# Altium schematic sheet Main.SchDoc
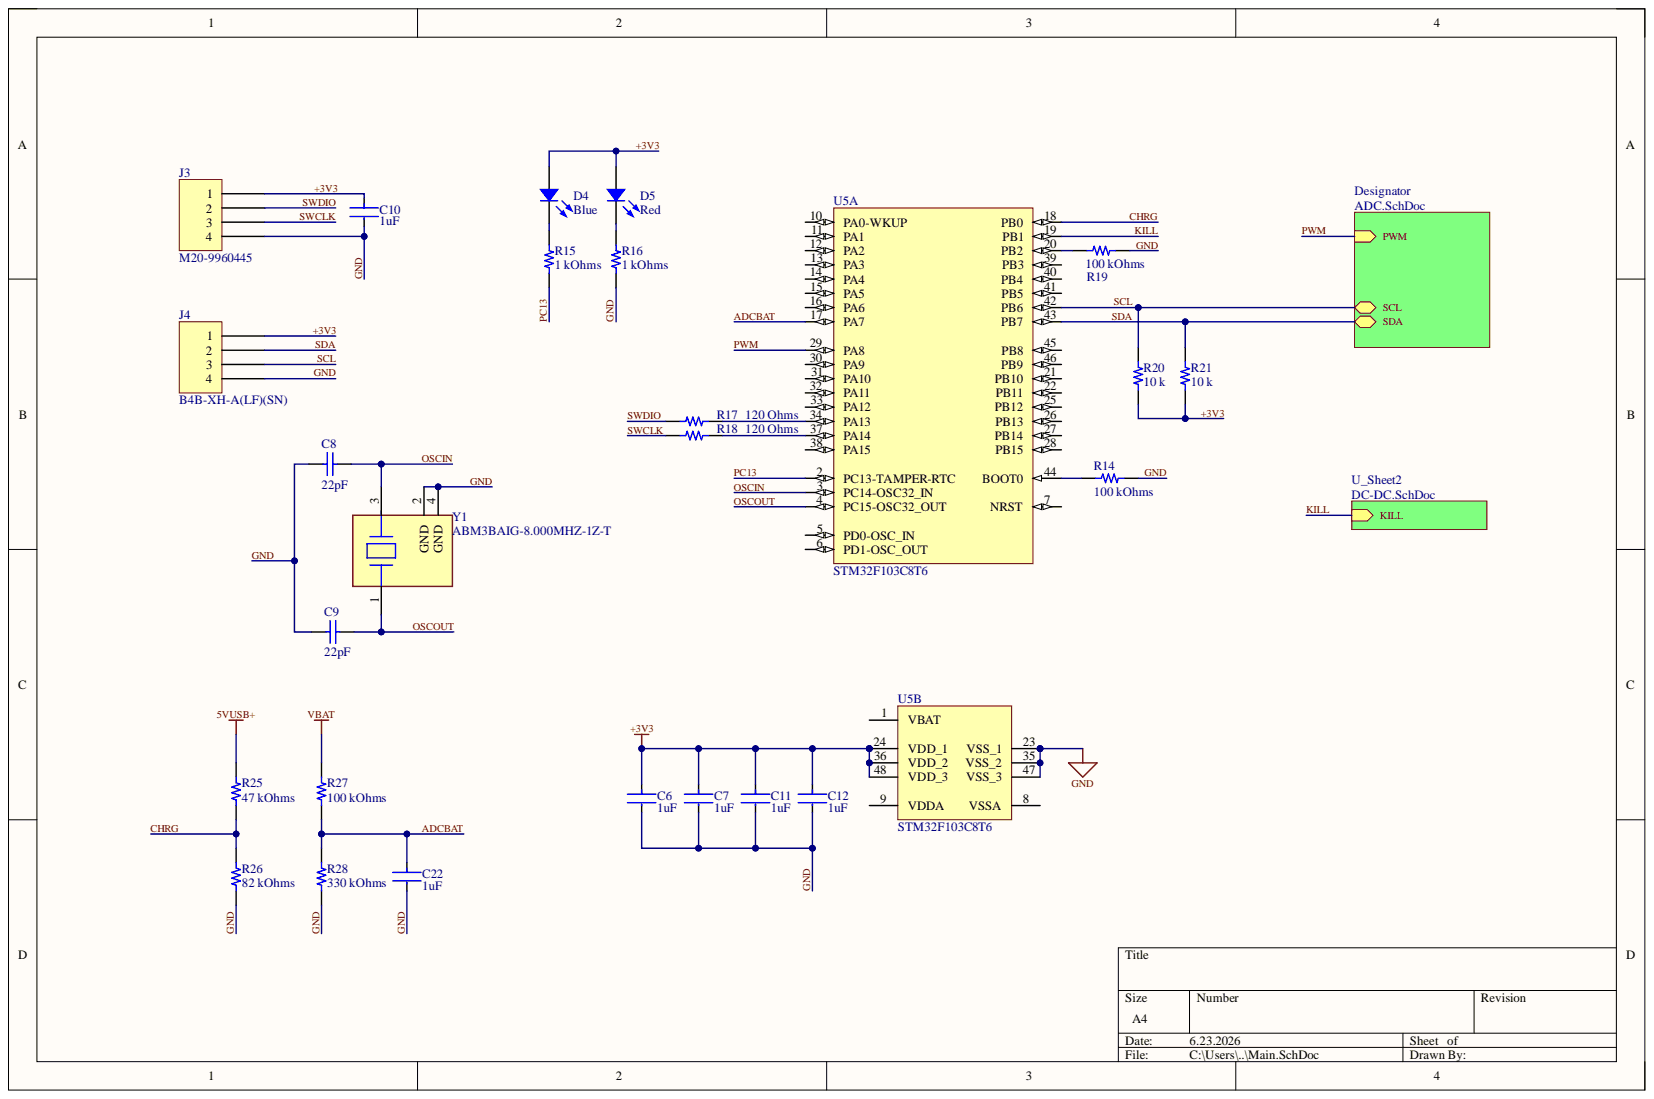
[diagram p0: Main.SchDoc]

## Parts
C6  Capacitance: 1uF  [FP-C0603C-CF-MFG]
C7  Capacitance: 1uF  [FP-C0603C-CF-MFG]
C8  22pF  [CAPC1608X90X35NL15T15]
C9  22pF  [CAPC1608X90X35NL15T15]
C10  Capacitance: 1uF  [FP-C0603C-CF-MFG]
C11  Capacitance: 1uF  [FP-C0603C-CF-MFG]
C12  Capacitance: 1uF  [FP-C0603C-CF-MFG]
C22  Capacitance: 1uF  [FP-C0603C-CF-MFG]
D4  Blue  [LED 0603_1608 YELLOW]
D5  Red  [LED 0603_1608 YELLOW]
J3  M20-9960445  [HARWIN M20-9960445]
J4  B4B-XH-A(LF)(SN)  [JST XH B4B-XH-A]
R14  100 kOhms  [KOA RES RK73 RN73 0603_1608]
R15  1 kOhms  [KOA RES RK73 RN73 0603_1608]
R16  1 kOhms  [KOA RES RK73 RN73 0603_1608]
R17  120 Ohms  [KOA RES RK73 RN73 0603_1608]
R18  120 Ohms  [KOA RES RK73 RN73 0603_1608]
R19  100 kOhms  [KOA RES RK73 RN73 0603_1608]
R20  10 k  [KOA RES RK73 RN73 0603_1608]
R21  10 k  [KOA RES RK73 RN73 0603_1608]
R25  47 kOhms  [KOA RES RK73 RN73 0603_1608]
R26  82 kOhms  [KOA RES RK73 RN73 0603_1608]
R27  100 kOhms  [KOA RES RK73 RN73 0603_1608]
R28  330 kOhms  [KOA RES RK73 RN73 0603_1608]
U5  STM32F103C8T6  [STM-LQFP48_L]  (parts A,B)
Y1  ABM3BAIG-8.000MHZ-1Z-T  [FP-ABM3BAIG-MFG]

## Nets
5VUSB+: R25.2
VBAT: R27.2
+3V3: C6.1 C7.1 C10.1 C11.1 C12.1 D4.1 D5.1 J3.1 J4.1 R20.1 R21.1 U5.24(VDD_1) U5.36(VDD_2) U5.48(VDD_3)
ADCBAT: C22.1 R27.1 R28.2 U5.17(PA7)
CHRG: R25.1 R26.2 U5.18(PB0)
GND: C6.2 C7.2 C8.1 C9.1 C10.2 C11.2 C12.2 C22.2 J3.4 J4.4 R14.1 R16.1 R19.2 R26.1 R28.1 U5.23(VSS_1) U5.35(VSS_2) U5.47(VSS_3) Y1.2(GND) Y1.4(GND)
KILL: U5.19(PB1)
OSCIN: C8.2 U5.3(PC14-OSC32_IN) Y1.3
OSCOUT: C9.2 U5.4(PC15-OSC32_OUT) Y1.1
PC13: R15.1 U5.2(PC13-TAMPER-RTC)
PWM: U5.29(PA8)
SCL: J4.3 R20.2 U5.42(PB6)
SDA: J4.2 R21.2 U5.43(PB7)
SWCLK: J3.3 R18.2
SWDIO: J3.2 R17.2
NetD4_2: D4.2 R15.2
NetD5_2: D5.2 R16.2
NetR14_2: R14.2 U5.44(BOOT0)
NetR17_1: R17.1 U5.34(PA13)
NetR18_1: R18.1 U5.37(PA14)
NetR19_1: R19.1 U5.20(PB2)
NetU5_1: U5.1(VBAT)
NetU5_5: U5.5(PD0-OSC_IN)
NetU5_6: U5.6(PD1-OSC_OUT)
NetU5_7: U5.7(NRST)
NetU5_8: U5.8(VSSA)
NetU5_9: U5.9(VDDA)
NetU5_10: U5.10(PA0-WKUP)
NetU5_11: U5.11(PA1)
NetU5_12: U5.12(PA2)
NetU5_13: U5.13(PA3)
NetU5_14: U5.14(PA4)
NetU5_15: U5.15(PA5)
NetU5_16: U5.16(PA6)
NetU5_21: U5.21(PB10)
NetU5_22: U5.22(PB11)
NetU5_25: U5.25(PB12)
NetU5_26: U5.26(PB13)
NetU5_27: U5.27(PB14)
NetU5_28: U5.28(PB15)
NetU5_30: U5.30(PA9)
NetU5_31: U5.31(PA10)
NetU5_32: U5.32(PA11)
NetU5_33: U5.33(PA12)
NetU5_38: U5.38(PA15)
NetU5_39: U5.39(PB3)
NetU5_40: U5.40(PB4)
NetU5_41: U5.41(PB5)
NetU5_45: U5.45(PB8)
NetU5_46: U5.46(PB9)

## Library data of the parts
C6 C7 C10-C12 C22 = CMP-2006-03086-2: C0603 1uF X8R 30ppm/°C 5.00% 50 V; Manufacturer: KEMET; Manufacturer Part Number: C0603C152J5HACTU; Automotive Grade: Grade 1; Material: Ceramic; Tolerance: 0.05; Value: 22uF; Voltage Rating: 50 V; Automotive: Yes; Category: Cap; Component Kind: Standard; ComponentLink2Description: Datasheet; ComponentLink2URL: https://api.kemet.com/component-edge/download/datasheet/C0603C100J5GACAUTO.pdf; Device Class L1: Passive Components; Device Class L2: Capacitors; Device Class L3: Ceramic Capacitors; Height: 0.87mm; Lead Free: Yes; Library Name: Altium Content Vault; Mouser Description: Cap Ceramic 0.0015uF 50V X8R 5% SMD 0603 150°C Paper T/R; Octopart: https://octopart.com/c0603c152j5hactu-kemet-12793173; Package: C0603C-CF; RoHS: TRUE; Temperature: -55°C to +125°C; Temperature Characteristic: X8R; Temperature Coefficient: 30ppm/°C; Temperature Range High: +125°C; Temperature Range Low: -55°C
C8 C9 = CMP-2006-04271-1: Manufacturer: AVX Interconnect / Elco; Part Number: 06035A220JAT4A; Component Kind: Standard; Library Name: Altium Content Vault
D4 D5 = LSM0603472V: LED RED CLEAR CHIP SMD; Manufacturer: Visual Communications Company - VCC; Color: Yellow; Configuration: Standard; Current - Test: 20mA; Database Table Name: LED - Indication; Lens Color: Colorless; Lens Size: 1.20mm x 0.80mm; Lens Style: Rectangle with Flat Top; Millicandela Rating: 110mcd; Viewing Angle: 120°; Voltage - Forward (Vf) (Typ): 2V; Wavelength - Dominant: 590nm; Component Kind: Standard; ComponentLink1Description: Datasheet; ComponentLink1URL: https://vcclite.com/wp-content/uploads/wpallimport/files/files/LSM0603472V%20(1).pdf; ComponentLink2Description: DigiKey Link; ComponentLink2URL: https://www.digikey.com/en/products/detail/visual-communications-company-vcc/LSM0603472V/9486726; Device Package: 0603 (1608 Metric); Height (Max): 0.65mm; LastUpdated: 05.04.2026 20:40:04; Lens Transparency: Clear; Library Name: Celestial Altium Library - altium_library.DbLib; Lifecycle Status: Active; Minimum Order: 1; Mounting Type: Surface Mount; Package / Case: 0603 (1608 Metric); Packaging: TapeAndReel; Part Number: LSM0603472V; Part Status: Active; PartId: 84; Price: 0.09; Series: LSM0603; Size / Dimension: 1.60mm L x 0.80mm W; Supplier 1: DigiKey; Supplier Device Package: Chip LED; Supplier Part Number 1: LSM0603472VCT-ND; Wavelength - Peak: 590nm
  D4: Value: Blue
  D5: Value: Red
J3 = M20-9960445: CONN HEADER R/A 4POS 2.54MM; Manufacturer: Harwin Inc.; Connector Type: Header, Cuttable; Contact Length - Mating: 0.240" (6.10mm); Contact Length - Post: 0.118" (3.00mm); Current Rating (Amps): 3A per Contact; Database Table Name: Connectors - Rectangular Headers Male Pins; Material Flammability Rating: UL94 V-0; Number of Positions: 4; Number of Positions Loaded: All; Pitch - Mating: 0.100" (2.54mm); Row Spacing - Mating: -40°C ~ 105°C; Shrouding: Unshrouded; Style: Board to Board or Cable; Component Kind: Standard; ComponentLink1Description: Datasheet; ComponentLink1URL: https://cdn.harwin.com/pdfs/Harwin_PC_Connectors_M20.pdf; ComponentLink2Description: DigiKey Link; ComponentLink2URL: https://www.digikey.com/en/products/detail/harwin-inc/M20-9960445/3921052; Contact Finish - Mating: Gold; Contact Material: Brass; Contact Shape: Square; Contact Type: Male Pin; Fastening Type: Push-Pull; Insulation Color: Black; Insulation Height: 0.100" (2.54mm); Insulation Material: Polyamide (PA6T), Nylon 6T; LastUpdated: 21.09.2022 19:23:20; Library Name: Celestial Altium Library - altium_library.DbLib; Lifecycle Status: Active; Minimum Order: 1; Mounting Type: Through Hole, Right Angle; Number of Rows: 1; Operating Temperature: -40°C ~ 105°C; Packaging: Bulk; Part Number: M20-9960445; Part Status: Active; PartId: 167; Price: 0.11; Series: M20; Supplier 1: DigiKey; Supplier Part Number 1: 952-3286-ND; Termination: Solder
J4 = B4B-XH-A(LF)(SN): CONN HEADER VERT 4POS 2.5MM; Manufacturer: JST Sales America Inc.; Contact Length - Post: 0.134" (3.40mm); Current Rating (Amps): 3A; Database Table Name: Connectors - Rectangular Headers Male Pins; Material Flammability Rating: UL94 V-0; Number of Positions: 4; Number of Positions Loaded: All; Pitch - Mating: 0.098" (2.50mm); Shrouding: Shrouded - 4 Wall; Style: Board to Cable/Wire; Voltage Rating: 250V; Component Kind: Standard; ComponentLink1Description: Datasheet; ComponentLink1URL: http://www.jst-mfg.com/product/pdf/eng/eXH.pdf; ComponentLink2Description: DigiKey Link; ComponentLink2URL: http://digikey.com/product-detail/en/jst-sales-america-inc/B4B-XH-A-LF-SN/455-2249-ND/1651047; Connector Type: Header; Contact Finish - Mating: Tin; Contact Finish - Post: Tin; Contact Material: Brass; Contact Shape: Square; Contact Type: Male Pin; Fastening Type: Detent Lock; Insulation Color: Natural; Insulation Height: 0.276" (7.00mm); Insulation Material: Polyamide (PA66), Nylon 6/6; LastUpdated: 12.01.2020 23:46:34; Library Name: Celestial Altium Library - altium_library.DbLib; Lifecycle Status: Active; Minimum Order: 1; Mounting Type: Through Hole; Number of Rows: 1; Operating Temperature: -25°C ~ 85°C; Packaging: Bulk; Part Number: B4B-XH-A(LF)(SN); Part Status: Active; PartId: 69; Price: 0.21; Series: XH; Supplier 1: DigiKey; Supplier Part Number 1: 455-2249-ND; Termination: Press-Fit, Solder
R14 R19 = RK73H1JTTD1153F: RES 100 KOHM 1% 1/10W 0603; Manufacturer: KOA Speer Electronics, Inc.; Database Table Name: Resistors - Surface Mount - 0603; Power (Watts): 0.1W, 1/10W; Temperature Coefficient: ±100ppm/°C; Component Kind: Standard; ComponentLink1Description: Datasheet; ComponentLink1URL: http://www.koaspeer.com/catimages/Products/RK73H/RK73H.pdf; ComponentLink2Description: DigiKey Link; ComponentLink2URL: https://www.digikey.com/en/products/detail/koa-speer-electronics-inc/RK73H1JTTD1153F/9845183; Composition: Thick Film; Device Package: 0603 (1608 Metric); Features: Automotive AEC-Q200; Height - Seated (Max): 0.022" (0.55mm); LastUpdated: 05.04.2026 21:23:47; Library Name: Celestial Altium Library - altium_library.DbLib; Lifecycle Status: Active; Minimum Order: 1; Number of Terminations: 2; Operating Temperature: -55°C ~ 155°C; Package / Case: 0603 (1608 Metric); Packaging: CutTape; Part Number: RK73H1JTTD1153F; Part Status: Active; PartId: 6643; Price: 0.01; Ratings: AEC-Q200; Resistance: 100 kOhms; Series: RK73H; Size / Dimension: 0.063" L x 0.031" W (1.60mm x 0.80mm); Supplier 1: DigiKey; Supplier Device Package: 0603; Supplier Part Number 1: 2019-RK73H1JTTD1153FCT-ND; Tolerance: ±1%; Value: 100 kOhms
R15 R16 = RK73H1JTTD1153F: RES 115K OHM 1% 1/10W 0603; Manufacturer: KOA Speer Electronics, Inc.; Database Table Name: Resistors - Surface Mount - 0603; Power (Watts): 0.1W, 1/10W; Temperature Coefficient: ±100ppm/°C; Component Kind: Standard; ComponentLink1Description: Datasheet; ComponentLink1URL: http://www.koaspeer.com/catimages/Products/RK73H/RK73H.pdf; ComponentLink2Description: DigiKey Link; ComponentLink2URL: https://www.digikey.com/en/products/detail/koa-speer-electronics-inc/RK73H1JTTD1153F/9845183; Composition: Thick Film; Device Package: 0603 (1608 Metric); Features: Automotive AEC-Q200; Height - Seated (Max): 0.022" (0.55mm); LastUpdated: 05.04.2026 21:23:47; Library Name: Celestial Altium Library - altium_library.DbLib; Lifecycle Status: Active; Minimum Order: 1; Number of Terminations: 2; Operating Temperature: -55°C ~ 155°C; Package / Case: 0603 (1608 Metric); Packaging: CutTape; Part Number: RK73H1JTTD1153F; Part Status: Active; PartId: 6643; Price: 0.01; Ratings: AEC-Q200; Resistance: 1 kOhms; Series: RK73H; Size / Dimension: 0.063" L x 0.031" W (1.60mm x 0.80mm); Supplier 1: DigiKey; Supplier Device Package: 0603; Supplier Part Number 1: 2019-RK73H1JTTD1153FCT-ND; Tolerance: ±1%; Value: 1 kOhms
R17 R18 = RK73H1JTTD1153F: RES 120 OHM 1% 1/10W 0603; Manufacturer: KOA Speer Electronics, Inc.; Database Table Name: Resistors - Surface Mount - 0603; Power (Watts): 0.1W, 1/10W; Temperature Coefficient: ±100ppm/°C; Component Kind: Standard; ComponentLink1Description: Datasheet; ComponentLink1URL: http://www.koaspeer.com/catimages/Products/RK73H/RK73H.pdf; ComponentLink2Description: DigiKey Link; ComponentLink2URL: https://www.digikey.com/en/products/detail/koa-speer-electronics-inc/RK73H1JTTD1153F/9845183; Composition: Thick Film; Device Package: 0603 (1608 Metric); Features: Automotive AEC-Q200; Height - Seated (Max): 0.022" (0.55mm); LastUpdated: 05.04.2026 21:23:47; Library Name: Celestial Altium Library - altium_library.DbLib; Lifecycle Status: Active; Minimum Order: 1; Number of Terminations: 2; Operating Temperature: -55°C ~ 155°C; Package / Case: 0603 (1608 Metric); Packaging: CutTape; Part Number: RK73H1JTTD1153F; Part Status: Active; PartId: 6643; Price: 0.01; Ratings: AEC-Q200; Resistance: 120 Ohms; Series: RK73H; Size / Dimension: 0.063" L x 0.031" W (1.60mm x 0.80mm); Supplier 1: DigiKey; Supplier Device Package: 0603; Supplier Part Number 1: 2019-RK73H1JTTD1153FCT-ND; Tolerance: ±1%; Value: 120 Ohms
R20 R21 = RK73H1JTTD1153F: RES 10KOHM 1% 1/10W 0603; Manufacturer: KOA Speer Electronics, Inc.; Database Table Name: Resistors - Surface Mount - 0603; Power (Watts): 0.1W, 1/10W; Resistance: 10 kOhms; Temperature Coefficient: ±100ppm/°C; Component Kind: Standard; ComponentLink1Description: Datasheet; ComponentLink1URL: http://www.koaspeer.com/catimages/Products/RK73H/RK73H.pdf; ComponentLink2Description: DigiKey Link; ComponentLink2URL: https://www.digikey.com/en/products/detail/koa-speer-electronics-inc/RK73H1JTTD1153F/9845183; Composition: Thick Film; Device Package: 0603 (1608 Metric); Features: Automotive AEC-Q200; Height - Seated (Max): 0.022" (0.55mm); LastUpdated: 05.04.2026 21:23:47; Library Name: Celestial Altium Library - altium_library.DbLib; Lifecycle Status: Active; Minimum Order: 1; Number of Terminations: 2; Operating Temperature: -55°C ~ 155°C; Package / Case: 0603 (1608 Metric); Packaging: CutTape; Part Number: RK73H1JTTD1153F; Part Status: Active; PartId: 6643; Price: 0.01; Ratings: AEC-Q200; Series: RK73H; Size / Dimension: 0.063" L x 0.031" W (1.60mm x 0.80mm); Supplier 1: DigiKey; Supplier Device Package: 0603; Supplier Part Number 1: 2019-RK73H1JTTD1153FCT-ND; Tolerance: ±1%; Value: 10 k
R25-R28 = RK73H1JTTD1153F: RES 115K OHM 1% 1/10W 0603; Manufacturer: KOA Speer Electronics, Inc.; Database Table Name: Resistors - Surface Mount - 0603; Power (Watts): 0.1W, 1/10W; Resistance: 1 kOhms; Temperature Coefficient: ±100ppm/°C; Component Kind: Standard; ComponentLink1Description: Datasheet; ComponentLink1URL: http://www.koaspeer.com/catimages/Products/RK73H/RK73H.pdf; ComponentLink2Description: DigiKey Link; ComponentLink2URL: https://www.digikey.com/en/products/detail/koa-speer-electronics-inc/RK73H1JTTD1153F/9845183; Composition: Thick Film; Device Package: 0603 (1608 Metric); Features: Automotive AEC-Q200; Height - Seated (Max): 0.022" (0.55mm); LastUpdated: 05.04.2026 21:23:47; Library Name: Celestial Altium Library - altium_library.DbLib; Lifecycle Status: Active; Minimum Order: 1; Number of Terminations: 2; Operating Temperature: -55°C ~ 155°C; Package / Case: 0603 (1608 Metric); Packaging: CutTape; Part Number: RK73H1JTTD1153F; Part Status: Active; PartId: 6643; Price: 0.01; Ratings: AEC-Q200; Series: RK73H; Size / Dimension: 0.063" L x 0.031" W (1.60mm x 0.80mm); Supplier 1: DigiKey; Supplier Device Package: 0603; Supplier Part Number 1: 2019-RK73H1JTTD1153FCT-ND; Tolerance: ±1%
  R25: Value: 47 kOhms
  R26: Value: 82 kOhms
  R27: Value: 100 kOhms
  R28: Value: 330 kOhms
U5 = CMP-0237-00215-3: ARM Cortex-M3 32-bit MCU, 64 KB Flash, 20 KB Internal RAM, 37 I/Os, 48-pin LQFP, -40 to 85 degC, Tray; A/D Converter: 2x12-bit (10 Channel); FLASH Size (Prog) (kB): 64; I/Os (High Current): 37; Internal RAM Size (kB): 20; Operating Frequency(F) (Processor speed) (MHz): 72; Supply Voltage(Vcc) min (V): 2; Component Kind: Standard; ComponentLink1Description: Manufacturer URL; ComponentLink1URL: http://www.st.com/web/en/home.html; ComponentLink2Description: Datasheet; ComponentLink2URL: http://www.st.com/st-web-ui/static/active/en/resource/technical/document/datasheet/CD00161566.pdf; ComponentLink3Description: Package Specification; ComponentLink3URL: http://www.st.com/st-web-ui/static/active/en/resource/technical/document/datasheet/DM00058181.pdf; Core: ARM Cortex-M3; DatasheetVersion: Rev. 16; Library Name: Altium Content Vault; Mounting Technology: Surface Mount; PackageDescription: 48-Pin Low Profile Quad Flat Package, 7 x 7 mm Body, 0.5 mm Pitch; PackageReference: LQFP48; PackageVersion: Rev. 6, 06/2013; Packing: Tray; PartNumber: STM32F103C8T6
Y1 = CMP-27762-004085-1: CRYSTAL 8.0000MHZ 18PF SMD; Component Kind: Standard; Library Name: Altium Content Vault
